# Altium schematic sheet Power_Supply.SchDoc(Power_Supply)
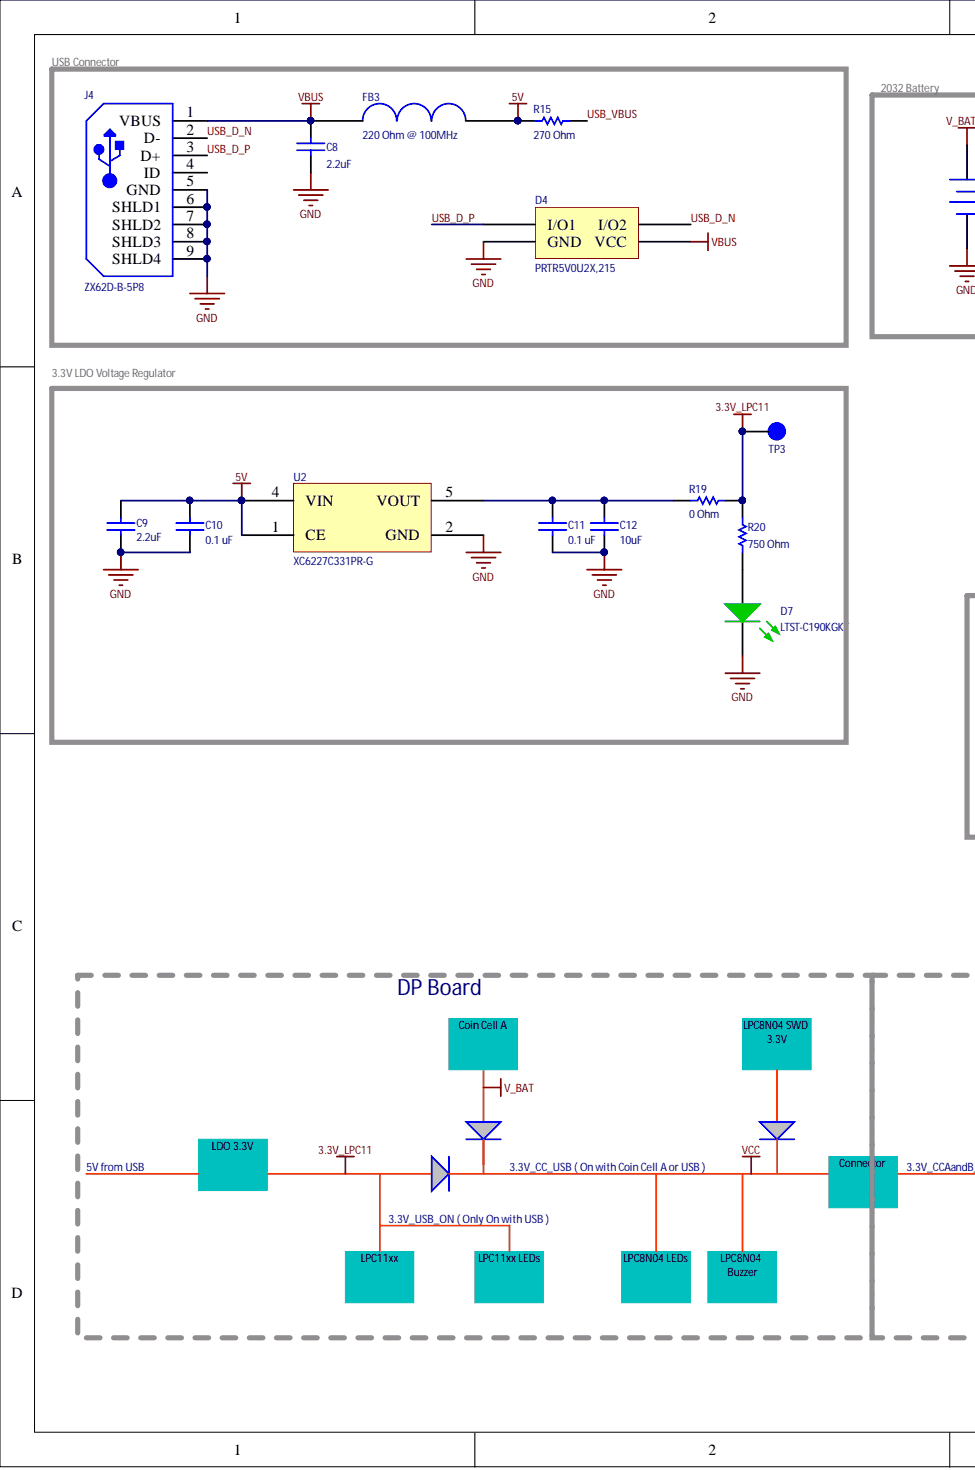
[diagram p0: Power_Supply.SchDoc, left]
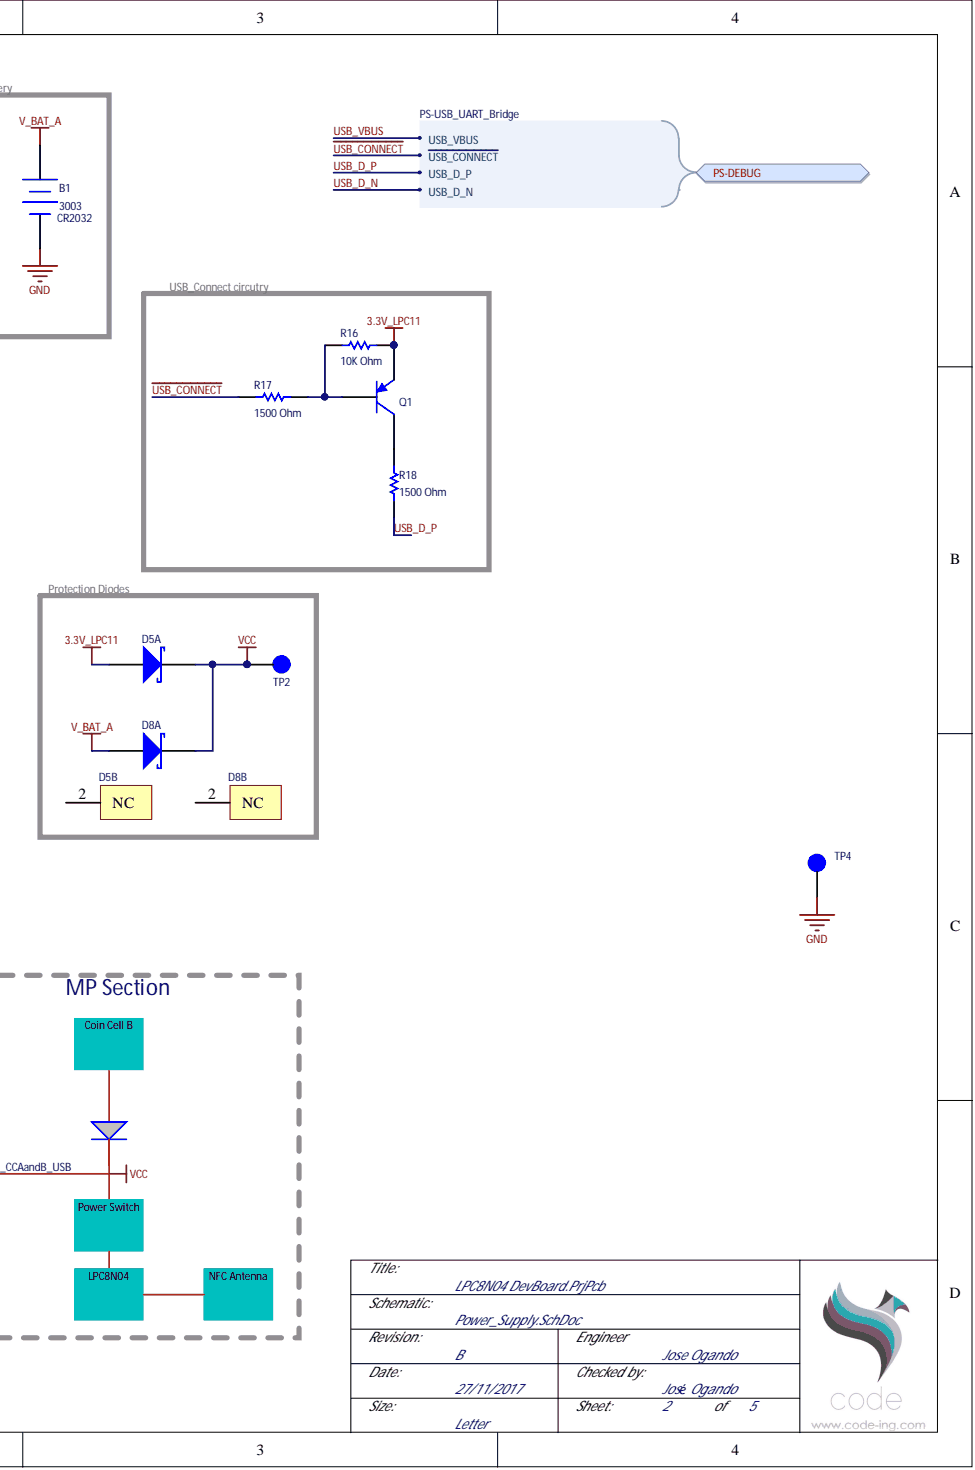
[diagram p1: Power_Supply.SchDoc, right]

## Parts
B1  3003  value CR2032  [3003]
C8  value 2.2uF  [CAPC0402(1005)60_N]  (hidden comment: CAP 2.2uF 10V 0402(1005))
C9  value 2.2uF  [CAPC0402(1005)60_N]  (hidden comment: CAP 2.2uF 10V 0402(1005))
C10  Capacitance: 0.1 uF  [CAPC1005]  (hidden comment: CC0402KRX7R7BB104)
C11  CC0402KRX7R7BB104  [CAPC1005]  (value not drawn)
C12  value 10uF  [CAPC0805(2012)145_L]  (hidden comment: CAP 10uF 10V 0805(2012))
D4  PRTR5V0U2X,215  [SOT143]
D5  BAT54  [NXP-SOT23-3_V]  (value not drawn)  (parts A,B)
D7  LTST-C190KGKT  [1.6X.8 SMT LED 0603]
D8  BAT54  [NXP-SOT23-3_V]  (value not drawn)  (parts A,B)
FB3  value 220 Ohm @ 100MHz  [0603_L]  (hidden comment: MI0603L221R-10)
J4  ZX62D-B-5P8  [HIRO-ZX62D-B-5P8_V]
Q1  PMBTA92,215  [SOT23-3]  (value not drawn)
R15  value 270 Ohm  [RESC0402(1005)_N]  (hidden comment: 270R 1% 0402(1005))
R16  value 10K Ohm  [RESC0402(1005)_N]  (hidden comment: 10K 5% 0402(1005))
R17  value 1500 Ohm  [RESC0402(1005)_N]  (hidden comment: 1K5 1% 0402(1005))
R18  value 1500 Ohm  [RESC0402(1005)_N]  (hidden comment: 1K5 1% 0402(1005))
R19  value 0 Ohm  [RESC0603(1608)_L]  (hidden comment: Jumper 0603(1608))
R20  value 750 Ohm  [RESC0402(1005)_N]  (hidden comment: 750R 1% 0402(1005))
TP2  TP  [SMT Test Point]  (no BOM)
TP3  TP  [SMT Test Point]  (no BOM)
TP4  TP  [SMT Test Point]  (no BOM)
U2  XC6227C331PR-G  [SOT89-5]

## Ports
PS-DEBUG, PS-DEBUG.USB_D_N, PS-DEBUG.USB_D_P, PS-DEBUG.USB_VBUS, PS-DEBUG.~{USB_CONNECT}

## Nets
3.3V_LPC11: D5.1 Q1.2 R16.2 R19.2 R20.2 TP3.1
5V: C9.2 C10.1 FB3.2 R15.1 U2.1(CE) U2.4(VIN)
GND: B1.2 C8.1 C9.1 C10.2 C11.2 C12.1 D4.1(GND) D7.2 J4.5(GND) J4.6(SHLD1) J4.7(SHLD2) J4.8(SHLD3) J4.9(SHLD4) TP4.1 U2.2(GND)
V_BAT_A: B1.1 D8.1
VBUS: C8.2 D4.4(VCC) FB3.1 J4.1(VBUS)
VCC: D5.3 D8.3 TP2.1
~{USB_CONNECT}: R17.2 ; port PS-DEBUG
USB_D_N: D4.3(I/O2) J4.2(D-) ; port PS-DEBUG
USB_D_P: D4.2(I/O1) J4.3(D+) R18.1 ; port PS-DEBUG
USB_VBUS: R15.2 ; port PS-DEBUG
NetC11_1: C11.1 C12.2 R19.1 U2.5(VOUT)
NetD7_1: D7.1 R20.1
NetQ1_1: Q1.1 R16.1 R17.1
NetQ1_3: Q1.3 R18.2
V_BAT:

Not connected: D5 2(NC); D8 2(NC); J4 4(ID)

## Library data of the parts
B1 = 3003: HOLDER BATTERY COIN 20MM DIA THM; Manufacturer: Keystone Electronics; Manufacturer Part #: 3003; Creation Date: 05-Jul-2017; Component Kind: Standard; Library Name: Battery Holders, Clips, Contacts.IntLib; Created by: eteofilo
C8 C9 = CMP-1034-02128-1: CAP 2.2uF 10V ±20% 0402 (1005 Metric) Thickness 0.6mm SMD; Tolerance: Â±20%; Case-Metric: 1005; Case-EIA: 0402; Technology: SMT; Rated Voltage: 10 V; Max Thickness: 0.6 mm; Library Name: Altium Content Vault; Component Kind: Standard
C10 C11 = CMP-0001-00074-1: Capacitor, Yageo, Ceramic, 0.1uF 10% 16V 0402 (1005) X7R; Polarity: Non-Polarized; Tolerance: 10 %; Technology: Ceramic; Status: Production; Rated Voltage: 16 V; Mounting Technology: Surface Mount; Library Name: Altium Content Vault; Dielectric: X7R; Component Kind: Standard; ClassName: Board Template Device; Category: Capacitor; Publisher: Altium
  C11: Capacitance: 0.1 uF
C12 = CMP-1036-04922-1: CAP 10uF 10V ±10% 0805 (2012 Metric) Thickness 1.45mm SMD; Tolerance: Â±10%; Case-Metric: 2012; Case-EIA: 0805; Technology: SMT; Rated Voltage: 10 V; Max Thickness: 1.45 mm; Library Name: Altium Content Vault; Component Kind: Standard
D4 = PRTR5V0U2X,215: TVS DIODE 5.5VWM SOT143B; Manufacturer: Nexperia USA Inc.; Manufacturer part #: PRTR5V0U2X,215; Library Name: TVS - Diodes.IntLib; Creation date: 03-Jul-2017; Component Kind: Standard; Created by: eteofilo
D5 D8 = CMP-0417-00004-1: Schottky Barrier Diode, 30 V, 2 uA, -55 to 150 degC, 3-Pin SOT23, RoHS, Tape and Reel; Manufacturer: NXP Semiconductors; VR (V): 30; VF (mV): 800; Trr (ns): 5; Rth(j-a) (K/W): 500; IR (uA): 2; Cd (pF): 10; Temperature Range: -55 to 150 degC; RoHS: TRUE; PartNumber: BAT54; Packing: Tape and Reel; PackageReference: SOT23-3; PackageDescription: 3-Pin Plastic Surface-mounted Package, Body 1.3 x 2.9 mm, Pitch 0.95 mm; Mounting Technology: Surface Mount; Library Name: Altium Content Vault; DatasheetVersion: Rev. 5; ComponentLink2URL: http://www.nxp.com/documents/data_sheet/BAT54_SER.pdf; ComponentLink2Description: Datasheet; ComponentLink1URL: http://www.nxp.com/; ComponentLink1Description: Manufacturer URL; Component Kind: Standard
D7 = LTST-C190KGKT: LED GREEN CLEAR 0603 SMD; Manufacturer: Lite-On Inc.; Manufacturer part #: LTST-C190KGKT; Creation date: 04-Jul-2017; Component Kind: Standard; Library Name: LED Indication - Discrete.IntLib; Created by: eteofilo
FB3 = MI0603L221R-10: FERRITE BEAD 220 OHM 0603 1LN; Manufacturer: Laird-Signal Integrity Products; Manufacturer Part Number: MI0603L221R-10; Library Name: Ferrite_Beads_and_Chips.IntLib; Creation Date: 04-Jul-2017; Component Kind: Standard; Created by: eteofilo
J4 = CMP-0029-00002-1: Right Angle Receptacle Connector, Micro USB, 30 V(AC), -30 to 85 degC, 5-Pin SMD, RoHS, Tape and Reel; Voltage Rating (DC): 35 V; Tolerance: 10%; Resistance: 7.5 Ohm; Capacitance: 1 uF; Width: 1.6 mm; Weight: 0.000571 oz; Voltage Rating: 35 V; RoHSCompliant: True; REACH SVHC: No SVHC; Radiation Hardening: No; Pins: 2; Packaging: Tape and Reel; PackageReference: ZX62D-B-5P8; Package Quantity: 2000; PackageDescription: USB Connector, 5 Position Surface Mount, Length 8 mm, Height 2.65 mm; Mount: Surface Mount; Min Operating Temperature: -55degC; Max Operating Temperature: 125degC; Library Name: Altium Content Vault; Length: 3.2 mm; Height: 1.8 mm; ESR: 7.5 Ohm; DatasheetVersion: Aug-16; ComponentLink3URL: https://www.hirose.com/product/en/download_file/key_name/ZX62D-B-5P8/category/Drawing%20(2D)/doc_file_id/36535/?file_category_id=6&item_id=02420034000&is_series=; ComponentLink3Description: Package Specification; ComponentLink2URL: https://www.hirose.com/product/en/download_file/key_name/ZX62D-B-5P8/category/Catalog/doc_file_id/31704/?file_category_id=5&item_id=02420034000&is_series=; ComponentLink2Description: Datasheet; ComponentLink1URL: https://www.hirose.com; ComponentLink1Description: Manufacturer URL; Component Kind: Standard; Case Code (Metric): 3216; Case Code (Imperial): 1206; Case/Package: 1206
Q1 = PMBTA92,215: TRANS PNP 300V 0.1A SOT23; Manufacturer: Nexperia USA Inc.; Manufacturer Part #: PMBTA92,215; Library Name: Transistors (BJT) - Single.IntLib; Creation Date: 18-Oct-2013; Component Kind: Standard; Created by: gmoreno
R15 = CMP-1011-00444-1: 270R 0.063W 1% 0402 (1005 Metric)  SMD; Case-Metric: 1005; Case-EIA: 0402; Tolerance: 1 %; Technology: SMT; Power: 0.063 W; Library Name: Altium Content Vault; Component Kind: Standard
R16 = CMP-1011-00098-1: 10K 0.063W 5% 0402 (1005 Metric)  SMD; Value: 10K Ohm; Case-Metric: 1005; Case-EIA: 0402; Tolerance: 5 %; Technology: SMT; Power: 0.063 W; Library Name: Altium Content Vault; Component Kind: Standard
R17 R18 = CMP-1011-00528-1: 1K5 0.063W 1% 0402 (1005 Metric)  SMD; Case-Metric: 1005; Case-EIA: 0402; Tolerance: 1 %; Technology: SMT; Power: 0.063 W; Library Name: Altium Content Vault; Component Kind: Standard
R19 = CMP-1012-00001-1: Jumper 0603 (1608 Metric); Manufacturer Part #: RC0603JR-070RL; Tolerance: None; Case-Metric: 1608; Case-EIA: 0603; Technology: SMT; Power: None; Library Name: Altium Content Vault; Component Kind: Standard
R20 = CMP-1011-00496-1: 750R 0.063W 1% 0402 (1005 Metric)  SMD; Case-Metric: 1005; Case-EIA: 0402; Tolerance: 1 %; Technology: SMT; Power: 0.063 W; Library Name: Altium Content Vault; Component Kind: Standard
TP2-TP4 = TP: PCB TEST POINT SMT; Library Name: PCB Test Points.IntLib; Creation date: 26-Apr-2012; Created by: gmoreno
U2 = XC6227C331PR-G: IC REG LDO 3.3V 0.7A SOT89-5; Manufacturer: Torex Semiconductor Ltd; Manufacturer part #: XC6227C331PR-G; Library Name: PMIC - Voltage Regulators - Linear (LDO).IntLib; Creation date: 04-Jul-2017; Component Kind: Standard; Created by: eteofilo
